# Altium schematic sheet Step Motor Kontrol Şematik.SchDoc("Step Motor Kontrol Şematik")
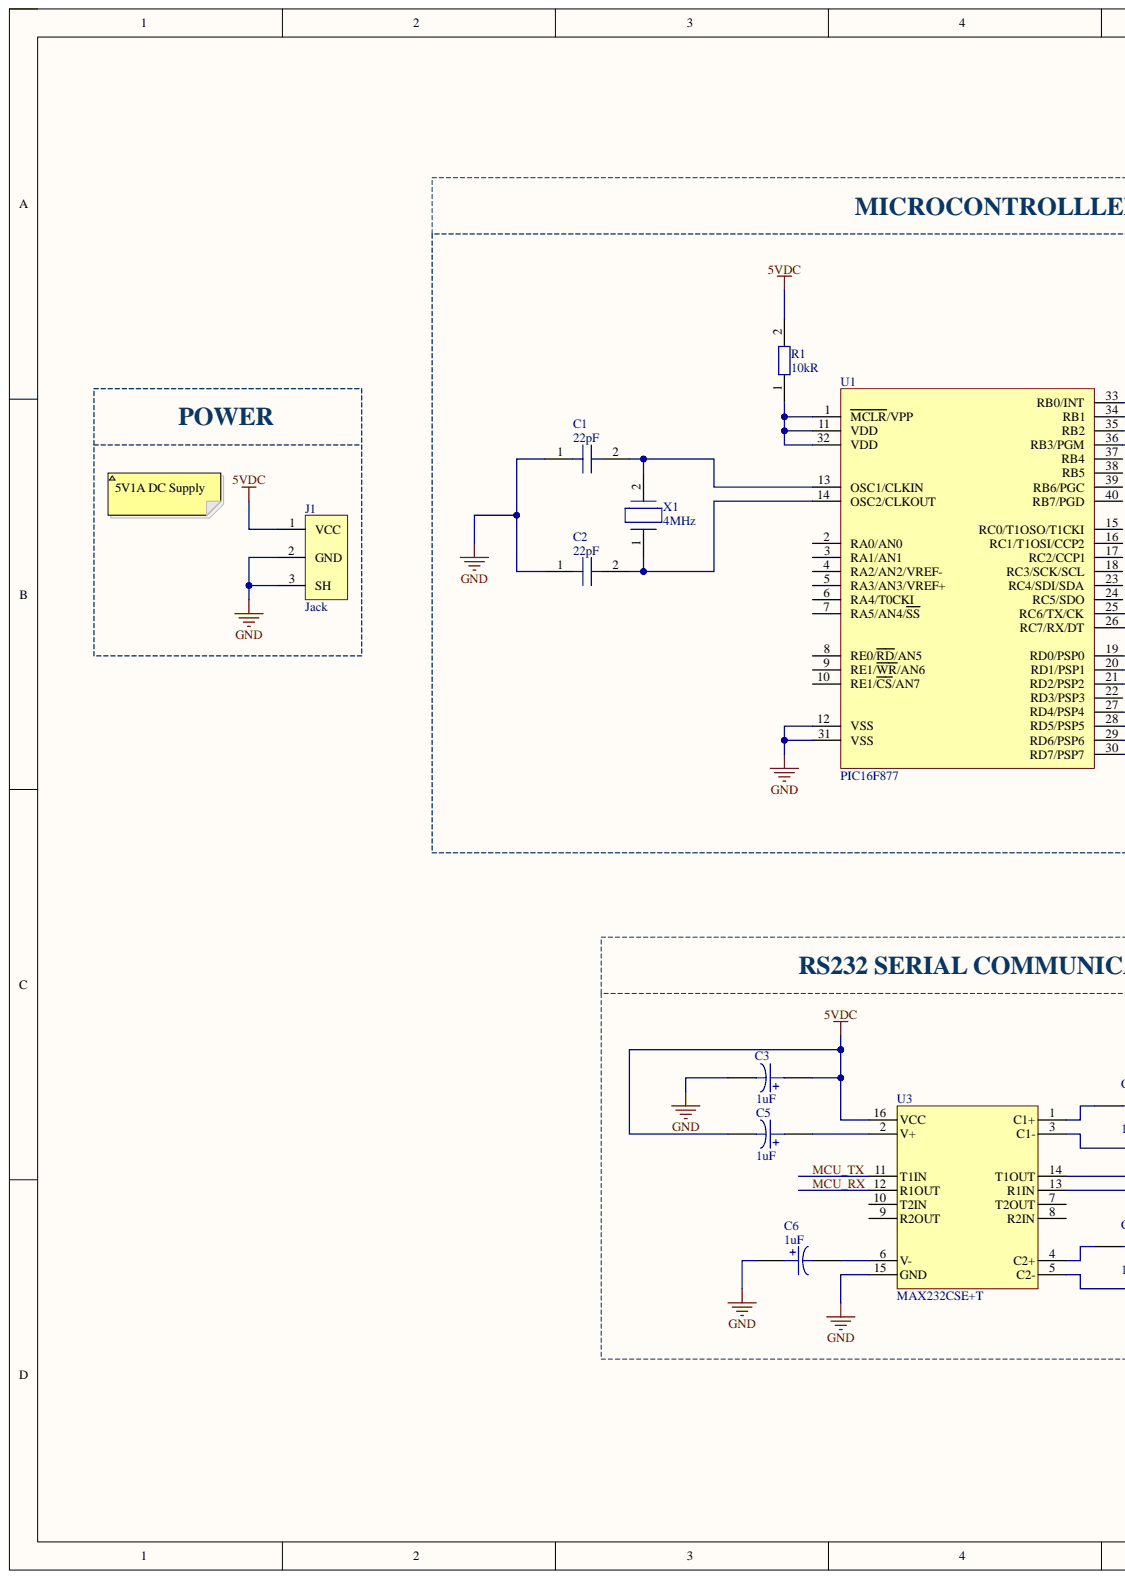
[diagram p0: Step Motor Kontrol Şematik.SchDoc, left]
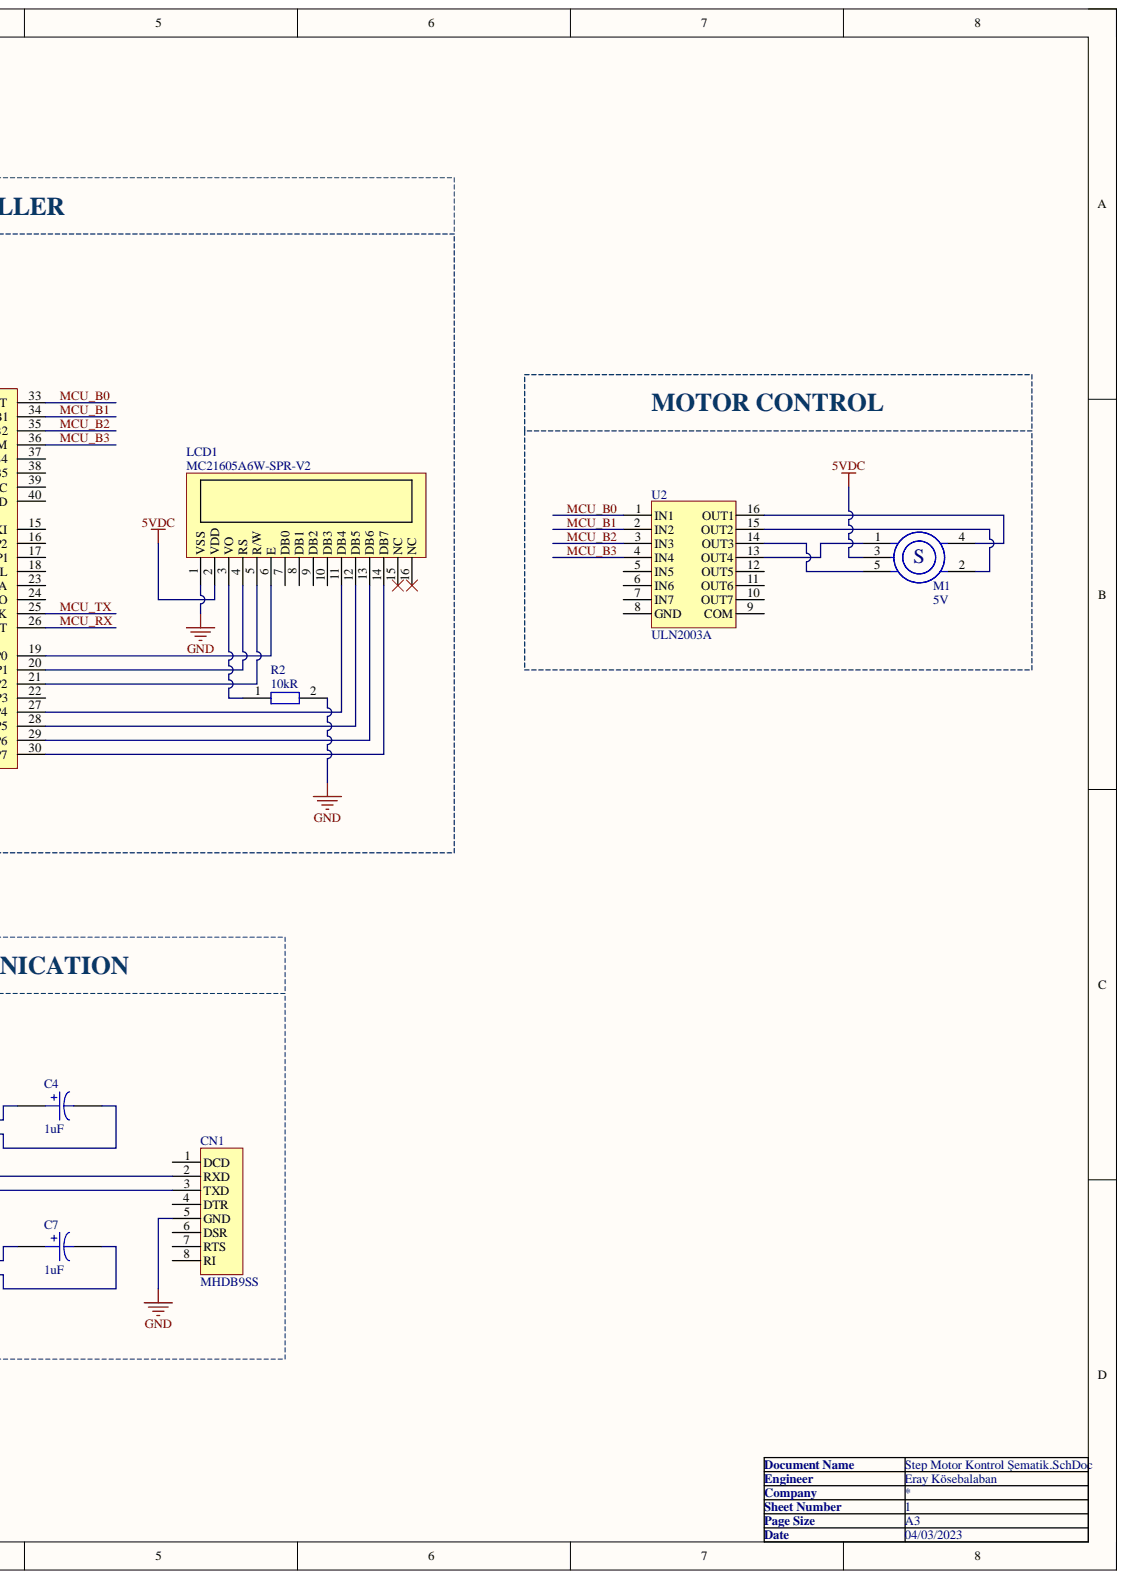
[diagram p1: Step Motor Kontrol Şematik.SchDoc, right]

## Parts
C1  Capacitance: 22pF  [22pF CER. CAP.]  (hidden comment: K220J15C0GF5TL2)
C2  Capacitance: 22pF  [22pF CER. CAP.]  (hidden comment: K220J15C0GF5TL2)
C3  Capacitance: 1uF  [CAP_RADIAL]  (hidden comment: ESK105M050AC3AA)
C4  Capacitance: 1uF  [CAP_RADIAL]  (hidden comment: ESK105M050AC3AA)
C5  Capacitance: 1uF  [CAP_RADIAL]  (hidden comment: ESK105M050AC3AA)
C6  Capacitance: 1uF  [CAP_RADIAL]  (hidden comment: ESK105M050AC3AA)
C7  Capacitance: 1uF  [CAP_RADIAL]  (hidden comment: ESK105M050AC3AA)
CN1  MHDB9SS  [DB9-FEMALE]
J1  PJ-037AH-SMT-TR  [JACK]  (value not drawn)
LCD1  MC21605A6W-SPR-V2  [2x16 LCD]
M1  Voltage: 5V  [JST XHP-5]  (hidden comment: MIKROE-1530)
R1  10k  Resistance: 10kR  [10k RES.]
R2  10k  Resistance: 10kR  [10k RES.]
U1  PIC16F877  [DIP-40]
U2  ULN2003A  [DIP-16L]
U3  MAX232CSE+T  [SOIC-16]
X1  Frequency: 4MHz  [HC-49]  (hidden comment: FC4STCBMF4.0)

## Nets
5VDC: C3.1 C5.2 J1.1 LCD1.2(VDD) M1.3(S) R1.2 U3.16(VCC)
GND: C1.1 C2.1 C3.2 C6.1 CN1.5(GND) J1.2 J1.3 LCD1.1(VSS) R2.2 U1.12(VSS) U1.31(VSS) U3.15(GND)
MCU_B0: U1.33(RB0/INT) U2.1(IN1)
MCU_B1: U1.34(RB1) U2.2(IN2)
MCU_B2: U1.35(RB2) U2.3(IN3)
MCU_B3: U1.36(RB3/PGM) U2.4(IN4)
MCU_RX: U1.26(RC7/RX/DT) U3.12(R1OUT)
MCU_TX: U1.25(RC6/TX/CK) U3.11(T1IN)
NetC1_2: C1.2 U1.13(OSC1/CLKIN) X1.2
NetC2_2: C2.2 U1.14(OSC2/CLKOUT) X1.1
NetC4_1: C4.1 U3.1(C1+)
NetC4_2: C4.2 U3.3(C1-)
NetC5_1: C5.1 U3.2(V+)
NetC6_2: C6.2 U3.6(V-)
NetC7_1: C7.1 U3.4(C2+)
NetC7_2: C7.2 U3.5(C2-)
NetCN1_2: CN1.2(RXD) U3.14(T1OUT)
NetCN1_3: CN1.3(TXD) U3.13(R1IN)
NetLCD1_3: LCD1.3(VO) R2.1
NetLCD1_4: LCD1.4(RS) U1.20(RD1/PSP1)
NetLCD1_5: LCD1.5(R/W) U1.21(RD2/PSP2)
NetLCD1_6: LCD1.6(E) U1.19(RD0/PSP0)
NetLCD1_11: LCD1.11(DB4) U1.27(RD4/PSP4)
NetLCD1_12: LCD1.12(DB5) U1.28(RD5/PSP5)
NetLCD1_13: LCD1.13(DB6) U1.29(RD6/PSP6)
NetLCD1_14: LCD1.14(DB7) U1.30(RD7/PSP7)
NetM1_1: M1.1 U2.13(OUT4)
NetM1_2: M1.2 U2.15(OUT2)
NetM1_4: M1.4 U2.16(OUT1)
NetM1_5: M1.5 U2.14(OUT3)
NetR1_1: R1.1 U1.1(MCLR/VPP) U1.11(VDD) U1.32(VDD)

Not connected: CN1 1(DCD) 4(DTR) 6(DSR) 7(RTS) 8(RI); LCD1 7(DB0) 8(DB1) 9(DB2) 10(DB3) 15(NC) 16(NC); U1 2(RA0/AN0) 3(RA1/AN1) 4(RA2/AN2/VREF-) 5(RA3/AN3/VREF+) 6(RA4/T0CKI) 7(RA5/AN4/SS) 8(RE0/RD/AN5) 9(RE1/WR/AN6) 10(RE1/CS/AN7) 15(RC0/T1OSO/T1CKI) 16(RC1/T1OSI/CCP2) 17(RC2/CCP1) 18(RC3/SCK/SCL) 22(RD3/PSP3) 23(RC4/SDI/SDA) 24(RC5/SDO) 37(RB4) 38(RB5) 39(RB6/PGC) 40(RB7/PGD); U2 5(IN5) 6(IN6) 7(IN7) 8(GND) 9(COM) 10(OUT7) 11(OUT6) 12(OUT5); U3 7(T2OUT) 8(R2IN) 9(R2OUT) 10(T2IN)

## Library data of the parts
C1 C2 = 22pF CER. CAP.: Cap Ceramic 22pF 50V C0G 5% Radial 2.5mm 125°C T/R; Manufacturer: Vishay BCcomponents; Designed by: Eray Kösebalaban; Lead Diameter: 0.5mm; Lead Length: 30mm; Lead/Base Style: Straight; Case/Package: Dipped; Category: Ceramic Capacitors; Component Kind: Standard; ComponentLink1Description: https://datasheet.ciiva.com/145/kseries-145764.pdf?src-supplier=Digi-Key; ComponentLink1URL: https://datasheet.ciiva.com/145/kseries-145764.pdf?src-supplier=Digi-Key; ComponentLink2Description: https://datasheet.ciiva.com/145/kseries-145765.pdf?src-supplier=Digi-Key; ComponentLink2URL: https://datasheet.ciiva.com/145/kseries-145765.pdf?src-supplier=Digi-Key; ComponentLink3Description: https://datasheet.ciiva.com/18442/70121924-18442245.pdf?src-supplier=Allied+Electronics; ComponentLink3URL: https://datasheet.ciiva.com/18442/70121924-18442245.pdf?src-supplier=Allied+Electronics; Construction: RADIAL; Depth: 2.5mm; Dielectric: C0G; Dielectric Material: Ceramic; Height: 4mm; Height - Seated (Max): 0.22inch; Lead Free: Lead Free; Lead Pitch: 2.5mm; Length: 4mm; Manufacturer Part Number: K220J15C0GF5TL2; Max Operating Temperature: 125°C; Min Operating Temperature: -55°C; Mount: Through Hole,PCB,Radial; Number of Pins: 2; Package Quantity: 4000; Packaging: Tape and Reel; Pricing 1: 1=0,27000, 10=0,18100, 50=0,10860, 100=0,09170, 500=0,06276, 1000=0,05552 (USD); Radiation Hardening: No; RoHS: Yes; RoHS Compliant: Yes; Series: K; Stock 1: 24200; Supplier 1: Digi-Key; Supplier Part Number 1: BC1005CT-ND; Termination: Radial; Tolerance: 5%; Voltage: 50V; Voltage Rating: 50V; Voltage Rating (DC): 50V; Weight: 0.005291oz; Width: 4mm; Library Name: Fan Kontrol Integrated_Library.IntLib
C3-C7 = CAP ALU ELEC. 1uF 50V: Cap Aluminum 1uF 50V 20% (5 X 11mm) Radial Aluminum Cylindrical Can 2mm 10mA 2000 hr 85°C Bulk; Manufacturer: KEMET; Designed by: Eray Kösebalaban; Dissipation Factor: 10%; Life (Hours): 2000Hour; Polarity: Polar; Case/Package: Radial; Category: Aluminum Electrolytic Capacitors; Component Kind: Standard; ComponentLink1Description: https://datasheet.ciiva.com/22627/1500292-22627197.pdf?src-supplier=Farnell; ComponentLink1URL: https://datasheet.ciiva.com/22627/1500292-22627197.pdf?src-supplier=Farnell; ComponentLink2Description: https://datasheet.ciiva.com/22621/1500292-22621006.pdf?src-supplier=Element14; ComponentLink2URL: https://datasheet.ciiva.com/22621/1500292-22621006.pdf?src-supplier=Element14; Diameter: 5mm; Height: 11mm; Height - Seated (Max): 0.492inch; Lead Pitch: 2mm; Length: 11mm; Manufacturer Part Number: ESK105M050AC3AA; Max Operating Temperature: 85°C; Min Operating Temperature: -40°C; Mount: Through Hole; Package Quantity: 10000; Packaging: Bulk; Pricing 1: 1=0,22000, 10=0,15600, 50=0,08880, 100=0,07350, 500=0,05156, 1000=0,04269, 2500=0,03973, 5000=0,03635 (USD); Radiation Hardening: No; Reach SVHC Compliant: Yes; Ripple Current: 10mA; RoHS: Yes; RoHS Compliant: Yes; Series: ESK; Stock 1: 192584; Supplier 1: Digi-Key; Supplier Part Number 1: 399-6596-ND; Termination: Radial; Tolerance: 20%; Voltage Rating: 50V; Voltage Rating (DC): 50V; Library Name: Step Motor Kontrol Şematik Kütüphane.SchLib
CN1 = RS232 DB9 CON.: D-sub Connector, Receptacle, 9POS; Manufacturer: MH Connectors; Body Material: Steel; Current Rating: 5A; Designed by: Eray Kösebalaban; Gender: Female; Number of Contacts: 9; Pitch: 2.77mm; Voltage Rating: 250V; Category: Connectors; Color: Black; Component Kind: Standard; ComponentLink1Description: https://www.distrelec.biz/Web/Downloads/20/91/MHDB SS.pdf?mime=application%2Fpdf&src-supplier=Distrelec; ComponentLink1URL: https://www.distrelec.biz/Web/Downloads/20/91/MHDB SS.pdf?mime=application%2Fpdf&src-supplier=Distrelec; Contact Material: Brass,Copper Alloy; Contact Plating: Gold; Depth: 10.7mm; Housing Material: Steel; Length: 30.81mm; Manufacturer Part Number: MHDB9SS; Max Operating Temperature: 105°C; Min Operating Temperature: -55°C; Mount: Cable; Orientation: Straight; Pricing 1: 1=0,46000, 10=0,39700, 25=0,36320, 50=0,34180, 100=0,32750, 250=0,29908, 450=0,28482, 950=0,23498, 2400=0,21362 (USD); Reach SVHC Compliant: Yes; RoHS: Yes; Series: MHDB; Supplier 1: Digi-Key; Supplier Part Number 1: 4672-MHDB9SS-ND; Termination: Solder; Width: 12.55mm; Library Name: Step Motor Kontrol Şematik Kütüphane.SchLib
J1 = PJ-037AH-SMT-TR: 2.0 x 6.3 mm, 5.0 A, Horizontal, Surface Mount (SMT), Dc Power Jack Connector; Manufacturer: CUI; Current Rating: 5A; Designed by: Eray Kösebalaban; Gender: Male; Number of Conductors: 2; Shielding: Unshielded; Voltage Rating: 24V; Insulation Resistance: 100MR; Case/Package: SMD/SMT; Category: Uncategorized; Color: Black; Component Kind: Standard; ComponentLink1Description: http://www.mouser.com/ds/2/670/pj-037ah-smt-516065.pdf?src-supplier=Mouser; ComponentLink1URL: http://www.mouser.com/ds/2/670/pj-037ah-smt-516065.pdf?src-supplier=Mouser; ComponentLink2Description: https://www.cui.com/product/resource/digikeypdf/pj-037ah-smt-tr.pdf?src-supplier=Digi-Key; ComponentLink2URL: https://www.cui.com/product/resource/digikeypdf/pj-037ah-smt-tr.pdf?src-supplier=Digi-Key; ComponentLink3Description: http://www.cui.com/product/resource/digikeypdf/pj-037ah-smt.pdf?src-supplier=Digi-Key; ComponentLink3URL: http://www.cui.com/product/resource/digikeypdf/pj-037ah-smt.pdf?src-supplier=Digi-Key; Connector Type: Jack; Contact Gender: Male; Contact Material: Copper; Contact Plating: Nickel; Flammability Rating: UL94 V-0; Housing Color: Black; Housing Material: Thermoplastic; Library Name: Wireless Security Detector Integrated_Library.IntLib; Manufacturer Part Number: PJ-037AH-SMT-TR; Max Operating Temperature: 85°C; Min Operating Temperature: -25°C; Mount: Surface Mount,Through Hole; Orientation: Horizontal; Package Quantity: 200; Packaging: Tape and Reel; RoHS: Yes; Supplier 1: Digi-Key; Supplier Part Number 1: CP-037AHPJCT-ND; Termination: Solder
LCD1 = 2x16 LCD: Display, Alphanumeric, 16X2, Nobacklight Rohs Compliant: Yes; Manufacturer: Midas; Designed by: Eray Kösebalaban; Display Format: 2x16; Voltage-Supply: 5V; Component Kind: Standard; ComponentLink1Description: http://datasheet.octopart.com/MC21605A6W-SPR-V2-Midas-datasheet-68419499.pdf?src-supplier=Rs; ComponentLink1URL: http://datasheet.octopart.com/MC21605A6W-SPR-V2-Midas-datasheet-68419499.pdf?src-supplier=Rs; Manufacturer Part Number: MC21605A6W-SPR-V2; Operating Temperature: -20°C ~ 70°C; Pricing 1: 1=11,47000, 10=10,72000, 25=10,41000, 50=9,40000, 100=8,85000, 250=7,78000, 500=7,53000 (USD); RoHS: No; Stock 1: 42; Supplier 1: Newark; Supplier Part Number 1: 02AC9919; Library Name: Step Motor Kontrol Şematik Kütüphane.SchLib
M1 = 28BYJ-48 STEP M.: Stepper Motors Unipolar Cylindrical 5V 50Ohm 5.625° 1Shaft; Manufacturer: mikroElektronika; Coil Resistance: 50R; Designed by: Eray Kösebalaban; Mounting Hole Spacing: 1.378inch; Coil Type: Unipolar; Component Kind: Standard; ComponentLink1Description: https://media.digikey.com/pdf/Data%20Sheets/Adafruit%20PDFs/858_Web.pdf?src-supplier=Digi-Key; ComponentLink1URL: https://media.digikey.com/pdf/Data%20Sheets/Adafruit%20PDFs/858_Web.pdf?src-supplier=Digi-Key; ComponentLink2Description: https://download.mikroe.com/documents/datasheets/step-motor-5v-28byj48-datasheet.pdf?src-supplier=Digi-Key; ComponentLink2URL: https://download.mikroe.com/documents/datasheets/step-motor-5v-28byj48-datasheet.pdf?src-supplier=Digi-Key; Manufacturer Part Number: MIKROE-1530; Pricing 1: 1=8,00000 (USD); RoHS: Yes; Stock 1: 34; Supplier 1: Digi-Key; Supplier Part Number 1: 1471-1491-ND; Termination: Wire Leads; Library Name: Step Motor Kontrol Şematik Kütüphane.SchLib
R1 R2 = 10k RES.: 10 kOhms ±5% 0.25W, 1/4W Through Hole Resistor Axial Carbon Film; Manufacturer: Yageo; Manufacturer Part Number: CFR25SJT-52-10K; Designed by: Eray Kösebalaban; Temperature Coefficient: -500/ +350ppm/°C; Category: Through-Hole Resistors; Component Kind: Standard; Composition: 0.25W, 1/4W; Operating Temperature: -55°C ~ -155°C; Power (Watts): 0.25W, 1/4W; Pricing 1: 1=0,10000, 10=0,06400, 25=0,04640, 50=0,03540, 100=0,02600, 250=0,01988, 500=0,01588, 1000=0,01170 (USD); RoHS: No; Size / Dimension: 0.075" Dia x 0.134" L (1.90mm x 3.40mm); Stock 1: 25082; Supplier 1: Digi-Key; Supplier Device Package: Axial; Supplier Part Number 1: 13-CFR25SJT-52-10KCT-ND; Tolerance: ±5%; Library Name: Fan Kontrol Integrated_Library.IntLib
U1 = PIC16F877: PIC16F Series 14 kB Flash 368 B RAM 20 MHz 8-Bit Microcontroller - PDIP-40; Manufacturer: Microchip; Manufacturer Part Number: PIC16F877-20/P; Access Time: 20us; Address Bus Width: 8b; Core Architecture: PIC; Designed by: Eray Kösebalaban; Frequency: 20MHz; Max Power Dissipation: 1W; Max Supply Voltage: 5.5V; Memory Size: 14KB; Min Supply Voltage: 4V; Nominal Supply Current: 1.6mA; Number of A/D Converters: 1; Number of ADC Channels: 8; Number of Programmable I/O: 33; Number of PWM Channels: 2; Number of Timers/Counters: 3; Number of USB Channels: 0; Oscillator Type: External; Peripherals: Brown-out Detect/Reset,POR,PWM,WDT,I2C; RAM Size: 368B; Watchdog Timer: Yes; Interface: USART,I2C,SPI,UART,SSP; Case/Package: PDIP; Category: Microcontrollers; Component Kind: Standard; ComponentLink1Description: https://datasheet.ciiva.com/19481/176882-19481594.pdf?src-supplier=Jameco; ComponentLink1URL: https://datasheet.ciiva.com/19481/176882-19481594.pdf?src-supplier=Jameco; ComponentLink2Description: https://datasheet.ciiva.com/7774/30292c-7774267.pdf?src-supplier=Element14; ComponentLink2URL: https://datasheet.ciiva.com/7774/30292c-7774267.pdf?src-supplier=Element14; ComponentLink3Description: https://datasheet.ciiva.com/14131/capic16f87x-e-14131992.pdf?src-supplier=Distrelec; ComponentLink3URL: https://datasheet.ciiva.com/14131/capic16f87x-e-14131992.pdf?src-supplier=Distrelec; ComponentLink4Description: https://datasheet.ciiva.com/4851/30292c-55665-4851245.pdf?src-supplier=Mouser; ComponentLink4URL: https://datasheet.ciiva.com/4851/30292c-55665-4851245.pdf?src-supplier=Mouser; ComponentLink5Description: https://datasheet.ciiva.com/7774/30292c-7774268.pdf?src-supplier=Element14; ComponentLink5URL: https://datasheet.ciiva.com/7774/30292c-7774268.pdf?src-supplier=Element14; ComponentLink6Description: https://datasheet.ciiva.com/3203/30292c-3203493.pdf?src-supplier=Avnet; ComponentLink6URL: https://datasheet.ciiva.com/3203/30292c-3203493.pdf?src-supplier=Avnet; ComponentLink7Description: https://datasheet.ciiva.com/16478/filehandler-aspxddocname-en011185-16478667.pdf?src-supplier=Future+Electronics; ComponentLink7URL: https://datasheet.ciiva.com/16478/filehandler-aspxddocname-en011185-16478667.pdf?src-supplier=Future+Electronics; ComponentLink8Description: https://datasheet.ciiva.com/29331/651653-da-01-en-ic-mcu-pic16f877-20-p-dip-40-mcp-29331196.pdf?src-supplier=Conrad; ComponentLink8URL: https://datasheet.ciiva.com/29331/651653-da-01-en-ic-mcu-pic16f877-20-p-dip-40-mcp-29331196.pdf?src-supplier=Conrad; Data Bus Width: 8b; Height: 4.06mm; Lead Free: Lead Free; Length: 52.45mm; Max Frequency: 20MHz; Max Operating Temperature: 70°C; Memory Type: FLASH; Min Operating Temperature: 0°C; Number of Bits: 8; Number of Pins: 40; Number of SPI Channels: 1; Number of UART Channels: 1; Number of I2C Channels: 1; Number of I/Os: 33; Package Quantity: 10; Packaging: Rail/Tube; Pricing 1: 1=9,08000 (USD); Radiation Hardening: No; Reach SVHC Compliant: Yes; RoHS: Yes; RoHS Compliant: Yes; Series: PIC® 16F; Stock 1: 153; Supplier 1: Digi-Key; Supplier Part Number 1: PIC16F877-20/P-ND; Supply Current: 1.6mA; Width: 14.22mm; Library Name: Fan Kontrol Integrated_Library.IntLib
U2 = ULN2003A: Trans Darlington NPN 50V 0.5A 16-Pin SOIC; Manufacturer: STMicroelectronics; Collector Emitter Saturation Voltage: 1.1V; Collector-emitter Voltage-Max: 1.6V; Current Rating: 500mA; Designed by: Eray Kösebalaban; Max Power Dissipation: 2.25W; Number of Elements: 7; Case/Package: DIP; Category: BJTs; Collector Emitter Breakdown Voltage: 50V; Collector Emitter Voltage (VCEO): 50V; Component Kind: Standard; ComponentLink1Description: https://datasheet.ciiva.com/15139/getdatasheetpartid-94437-15139993.pdf?src-supplier=Verical; ComponentLink1URL: https://datasheet.ciiva.com/15139/getdatasheetpartid-94437-15139993.pdf?src-supplier=Verical; ComponentLink2Description: https://datasheet.ciiva.com/29305/177970-da-01-en-uln2003-29305675.pdf?src-supplier=Conrad; ComponentLink2URL: https://datasheet.ciiva.com/29305/177970-da-01-en-uln2003-29305675.pdf?src-supplier=Conrad; ComponentLink3Description: https://datasheet.ciiva.com/1701/cd00001244-1701923.pdf?src-supplier=Digi-Key; ComponentLink3URL: https://datasheet.ciiva.com/1701/cd00001244-1701923.pdf?src-supplier=Digi-Key; ComponentLink4Description: https://datasheet.ciiva.com/14180/luuln2001-04-data-e-14180135.pdf?src-supplier=Distrelec; ComponentLink4URL: https://datasheet.ciiva.com/14180/luuln2001-04-data-e-14180135.pdf?src-supplier=Distrelec; ComponentLink5Description: https://datasheet.ciiva.com/4459/cd00001244-4459193.pdf?src-supplier=Mouser; ComponentLink5URL: https://datasheet.ciiva.com/4459/cd00001244-4459193.pdf?src-supplier=Mouser; ComponentLink6Description: https://datasheet.ciiva.com/6884/1690348-6884665.pdf?src-supplier=Newark; ComponentLink6URL: https://datasheet.ciiva.com/6884/1690348-6884665.pdf?src-supplier=Newark; ComponentLink7Description: https://datasheet.ciiva.com/1193/1690348-1193404.pdf?src-supplier=Element14; ComponentLink7URL: https://datasheet.ciiva.com/1193/1690348-1193404.pdf?src-supplier=Element14; Contact Plating: Gold; Height: 4.59mm; Lead Free: Lead Free; Length: 20mm; Manufacturer Part Number: ULN2003A; Max Collector Current: 500mA; Max Operating Temperature: 85°C; Min Operating Temperature: -40°C; Mount: Through Hole; Number of Pins: 16; Output Current: 500mA; Output Voltage: 50V; Package Quantity: 1000; Packaging: Rail/Tube; Polarity: NPN; Pricing 1: 1=0,77000, 10=0,68000, 25=0,63880, 100=0,52130, 250=0,48424, 500=0,41212, 1000=0,32970, 2500=0,29879, 5000=0,29160 (USD); Radiation Hardening: No; Reach SVHC Compliant: Yes; RoHS: Yes; RoHS Compliant: Yes; Series: -; Stock 1: 38439; Supplier 1: Digi-Key; Supplier Part Number 1: 497-2344-5-ND; Voltage Rating (DC): 50V; Weight: 0.057419oz; Width: 7.1mm; Library Name: Step Motor Kontrol Şematik Kütüphane.SchLib
U3 = MAX232CSE+T: IC RS-232 DRVR/RCVR 16-SOIC; Manufacturer: Maxim; Data Rate: 120Kbps; Designed by: Eray Kösebalaban; Logic Function: Transceiver,Receiver; Max Supply Voltage: 5.5V; Min Supply Voltage: 4.5V; Nominal Supply Current: 10mA; Number of Drivers: 2; Number of Transceivers: 1; Number of I/Os: 8; Operating Supply Voltage: 5V; Propagation Delay: 1us; Receiver Hysteresis: 500mV; Simplex/Duplex: Full Duplex; Case/Package: SOIC; Category: RS-232 / RS-422 / RS-485 Interface ICs; Component Kind: Standard; ComponentLink1Description: https://datasheet.ciiva.com/22828/0900766b810e269f-22828272.pdf?src-supplier=Rs; ComponentLink1URL: https://datasheet.ciiva.com/22828/0900766b810e269f-22828272.pdf?src-supplier=Rs; ComponentLink2Description: https://datasheet.ciiva.com/4784/max220-max249-4784855.pdf?src-supplier=Mouser; ComponentLink2URL: https://datasheet.ciiva.com/4784/max220-max249-4784855.pdf?src-supplier=Mouser; ComponentLink3Description: https://datasheet.ciiva.com/18624/max220-max249-18624728.pdf?src-supplier=Digi-Key; ComponentLink3URL: https://datasheet.ciiva.com/18624/max220-max249-18624728.pdf?src-supplier=Digi-Key; ComponentLink4Description: https://datasheet.ciiva.com/18624/max220-max249-18624729.pdf?src-supplier=Digi-Key; ComponentLink4URL: https://datasheet.ciiva.com/18624/max220-max249-18624729.pdf?src-supplier=Digi-Key; ComponentLink5Description: https://datasheet.ciiva.com/18624/max220-max249-18624727.pdf?src-supplier=Digi-Key; ComponentLink5URL: https://datasheet.ciiva.com/18624/max220-max249-18624727.pdf?src-supplier=Digi-Key; Contact Plating: Tin,Matte; Height: 1.5mm; Lead Free: Lead Free; Length: 10mm; Manufacturer Part Number: MAX232CSE+T; Max Operating Temperature: 70°C; Min Operating Temperature: 0°C; Mount: Surface Mount; Number of Pins: 16; Number of Receivers: 2; Number of Transmitters: 2; Package Quantity: 2500; Packaging: Tape and Reel; Pricing 1: 1=5,35000, 10=4,80800, 25=4,54480, 100=3,93900, 250=3,73700, 500=3,35320, 1000=3,03000 (USD); Radiation Hardening: No; RoHS: Yes; RoHS Compliant: Yes; Series: -; Stock 1: 8280; Supplier 1: Digi-Key; Supplier Part Number 1: MAX232CSE+TCT-ND; Supply Current: 10mA; Width: 4mm; Interface: RS-232; Library Name: Step Motor Kontrol Şematik Kütüphane.SchLib
X1 = CRYSTAL: Crystal 4MHz ±30ppm (Tol) ±50ppm (Stability) 20pF FUND 200Ohm 2-Pin HC-49/SLF Thru-Hole; Manufacturer: Fox Electronics; Designed by: Eray Kösebalaban; ESR (Equivalent Series Resistance): 150 Ohms; Load Capacitance: 22pF; Component Kind: Standard; Frequency Stability: ±50ppm; Frequency Tolerance: ±30ppm; Manufacturer Part Number: FC4STCBMF4.0; Operating Temperature: -20°C ~ 70°C; Pricing 1: 1=0,38000, 10=0,31600, 50=0,28400, 100=0,25250, 500=0,23988, 1000=0,20200, 2500=0,18938, 5000=0,18306, 10000=0,17675 (USD); Stock 1: 9276; Supplier 1: Digi-Key; Supplier Part Number 1: 631-FC4STCBMF4.0-ND; Library Name: Fan Kontrol Integrated_Library.IntLib
